# Altium schematic sheet 06-Si5341_PLL.SchDoc(06-Si5341_PLL)
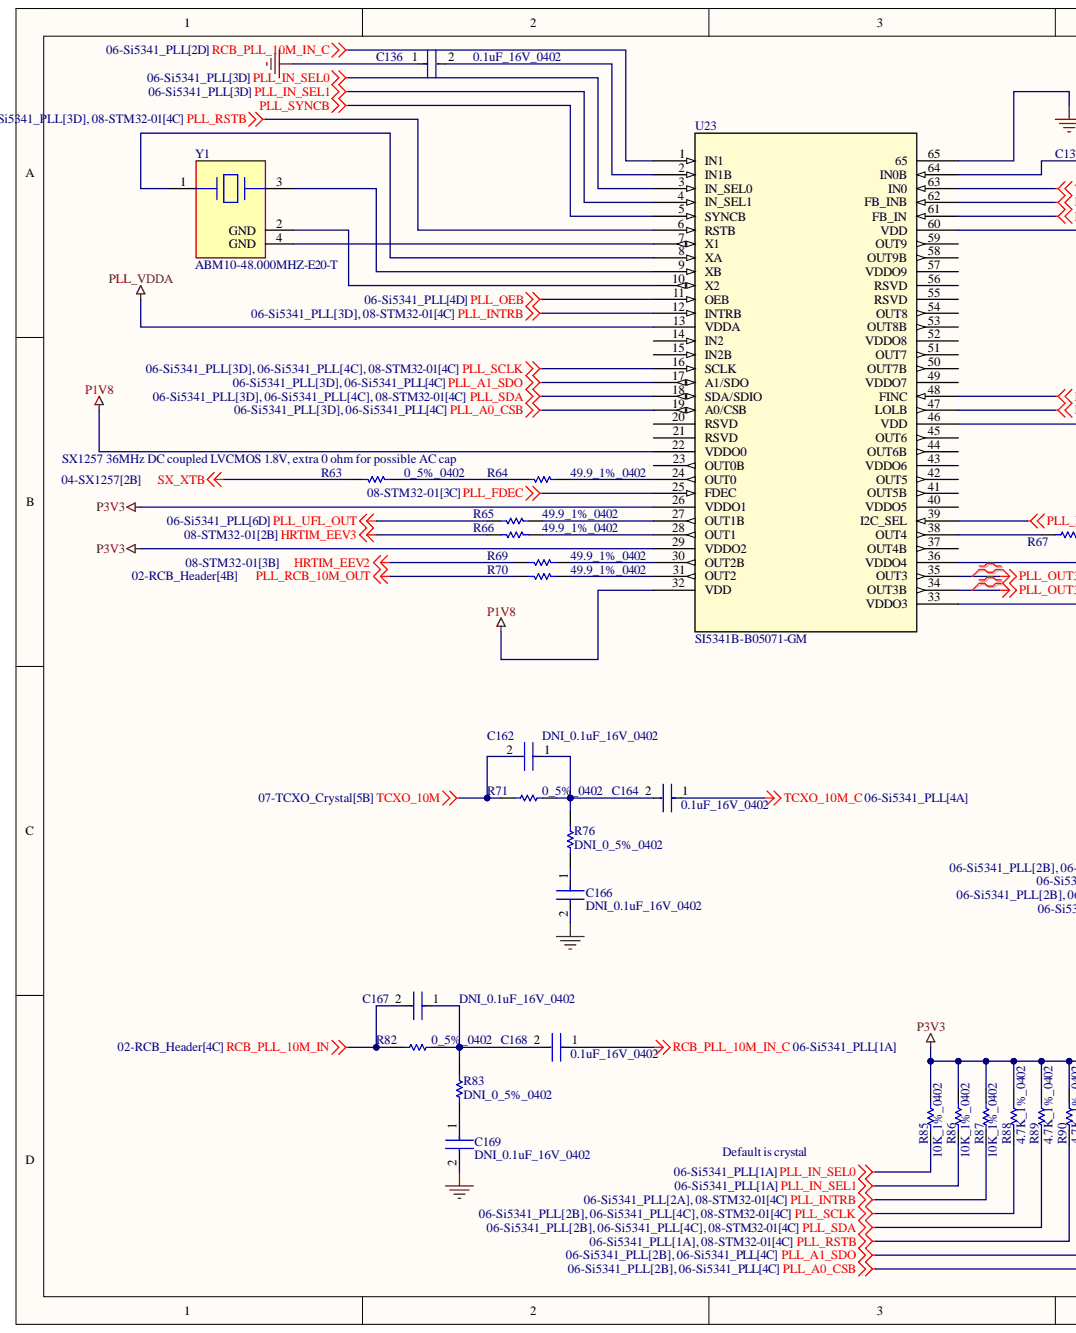
[diagram p0: 06-Si5341_PLL.SchDoc, left]
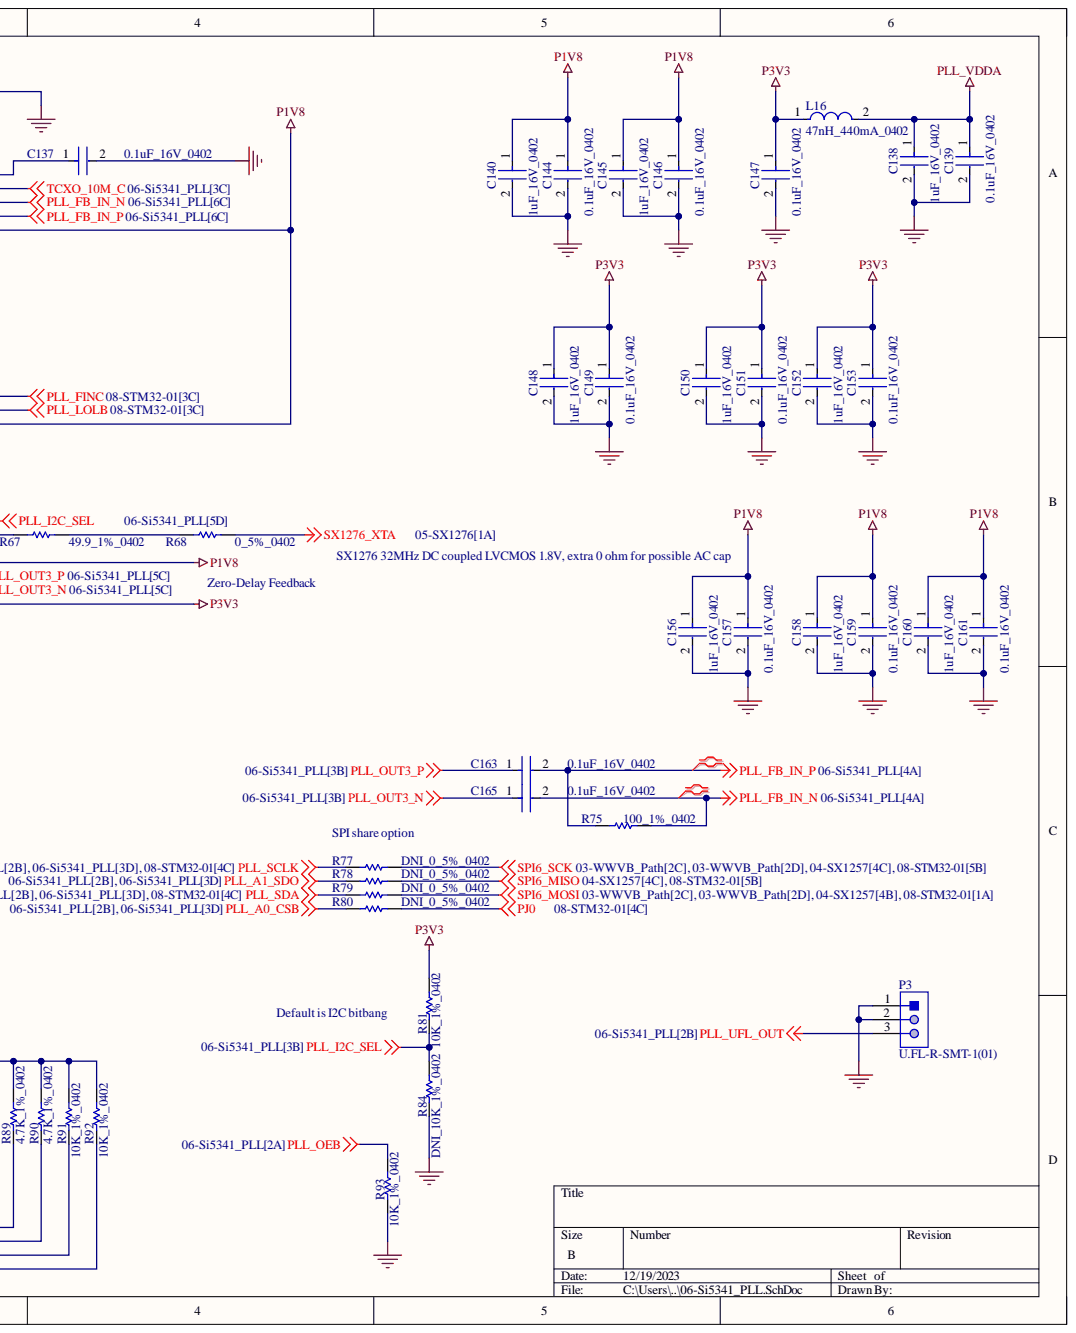
[diagram p1: 06-Si5341_PLL.SchDoc, right]

## Parts
C136  0.1uF_16V_0402  [FP-C0402-BB-MFG]
C137  0.1uF_16V_0402  [FP-C0402-BB-MFG]
C138  1uF_16V_0402  [FP-0402-L_1_0_0_05-W_0_5-IPC_A]
C139  0.1uF_16V_0402  [FP-C0402-BB-MFG]
C140  1uF_16V_0402  [FP-0402-L_1_0_0_05-W_0_5-IPC_A]
C144  0.1uF_16V_0402  [FP-C0402-BB-MFG]
C145  1uF_16V_0402  [FP-0402-L_1_0_0_05-W_0_5-IPC_A]
C146  0.1uF_16V_0402  [FP-C0402-BB-MFG]
C147  0.1uF_16V_0402  [FP-C0402-BB-MFG]
C148  1uF_16V_0402  [FP-0402-L_1_0_0_05-W_0_5-IPC_A]
C149  0.1uF_16V_0402  [FP-C0402-BB-MFG]
C150  1uF_16V_0402  [FP-0402-L_1_0_0_05-W_0_5-IPC_A]
C151  0.1uF_16V_0402  [FP-C0402-BB-MFG]
C152  1uF_16V_0402  [FP-0402-L_1_0_0_05-W_0_5-IPC_A]
C153  0.1uF_16V_0402  [FP-C0402-BB-MFG]
C156  1uF_16V_0402  [FP-0402-L_1_0_0_05-W_0_5-IPC_A]
C157  0.1uF_16V_0402  [FP-C0402-BB-MFG]
C158  1uF_16V_0402  [FP-0402-L_1_0_0_05-W_0_5-IPC_A]
C159  0.1uF_16V_0402  [FP-C0402-BB-MFG]
C160  1uF_16V_0402  [FP-0402-L_1_0_0_05-W_0_5-IPC_A]
C161  0.1uF_16V_0402  [FP-C0402-BB-MFG]
C162  DNI_0.1uF_16V_0402  [FP-C0402-BB-MFG]  (no BOM)
C163  0.1uF_16V_0402  [FP-C0402-BB-MFG]
C164  0.1uF_16V_0402  [FP-C0402-BB-MFG]
C165  0.1uF_16V_0402  [FP-C0402-BB-MFG]
C166  DNI_0.1uF_16V_0402  [FP-C0402-BB-MFG]  (no BOM)
C167  DNI_0.1uF_16V_0402  [FP-C0402-BB-MFG]  (no BOM)
C168  0.1uF_16V_0402  [FP-C0402-BB-MFG]
C169  DNI_0.1uF_16V_0402  [FP-C0402-BB-MFG]  (no BOM)
L16  47nH_440mA_0402  [FP-LQW15AN_80-MFG]
P3  U.FL-R-SMT-1(01)  [HRS-U.FL-R-SMT-3_V]
R63  0_5%_0402  [RESC1005X40X25NL05T10]
R64  49.9_1%_0402  [RESC1005X40X25NL10T10]
R65  49.9_1%_0402  [RESC1005X40X25NL10T10]
R66  49.9_1%_0402  [RESC1005X40X25NL10T10]
R67  49.9_1%_0402  [RESC1005X40X25NL10T10]
R68  0_5%_0402  [RESC1005X40X25NL05T10]
R69  49.9_1%_0402  [RESC1005X40X25NL10T10]
R70  49.9_1%_0402  [RESC1005X40X25NL10T10]
R71  0_5%_0402  [RESC1005X40X25NL05T10]
R75  100_1%_0402  [RESC1005X40X25NL05T10]
R76  DNI_0_5%_0402  [RESC1005X40X25NL05T10]  (no BOM)
R77  DNI_0_5%_0402  [RESC1005X40X25NL05T10]  (no BOM)
R78  DNI_0_5%_0402  [RESC1005X40X25NL05T10]  (no BOM)
R79  DNI_0_5%_0402  [RESC1005X40X25NL05T10]  (no BOM)
R80  DNI_0_5%_0402  [RESC1005X40X25NL05T10]  (no BOM)
R81  10K_1%_0402  [RESC1005X40X25NL10T10]
R82  0_5%_0402  [RESC1005X40X25NL05T10]
R83  DNI_0_5%_0402  [RESC1005X40X25NL05T10]  (no BOM)
R84  DNI_10K_1%_0402  [RESC1005X40X25NL10T10]  (no BOM)
R85  10K_1%_0402  [RESC1005X40X25NL10T10]
R86  10K_1%_0402  [RESC1005X40X25NL10T10]
R87  10K_1%_0402  [RESC1005X40X25NL10T10]
R88  4.7K_1%_0402  [RESC1005X40X25NL10T10]
R89  4.7K_1%_0402  [RESC1005X40X25NL10T10]
R90  4.7K_1%_0402  [RESC1005X40X25NL10T10]
R91  10K_1%_0402  [RESC1005X40X25NL10T10]
R92  10K_1%_0402  [RESC1005X40X25NL10T10]
R93  10K_1%_0402  [RESC1005X40X25NL10T10]
U23  SI5341B-B05071-GM  [QFN64_SI5341_SIL_SKY]
Y1  ABM10-48.000MHZ-E20-T  [FP-ABM10-MFG]

## Nets
GND: C136.1 C137.2 C138.2 C139.2 C140.2 C144.2 C145.2 C146.2 C147.2 C148.2 C149.2 C150.2 C151.2 C152.2 C153.2 C156.2 C157.2 C158.2 C159.2 C160.2 C161.2 C166.2 C169.2 P3.1 P3.2 R84.1 R93.1 U23.65
HRTIM_EEV2: R69.1
HRTIM_EEV3: R66.1
P1V8: C140.1 C144.1 C145.1 C146.1 C156.1 C157.1 C158.1 C159.1 C160.1 C161.1 U23.22(VDDO0) U23.32(VDD) U23.36(VDDO4) U23.46(VDD) U23.60(VDD)
P3V3: C147.1 C148.1 C149.1 C150.1 C151.1 C152.1 C153.1 L16.1 R81.2 R85.2 R86.2 R87.2 R88.2 R89.2 R90.2 R91.2 R92.2 U23.26(VDDO1) U23.29(VDDO2) U23.33(VDDO3)
PJ0: R80.2
PLL_A0_CSB: R80.1 R92.1 U23.19(A0/CSB)
PLL_A1_SDO: R78.1 R91.1 U23.17(A1/SDO)
PLL_FB_IN_N: C165.2 R75.2 U23.62(FB_INB)
PLL_FB_IN_P: C163.2 R75.1 U23.61(FB_IN)
PLL_FDEC: U23.25(FDEC)
PLL_FINC: U23.48(FINC)
PLL_I2C_SEL: R81.1 R84.2 U23.39(I2C_SEL)
PLL_IN_SEL0: R85.1 U23.3(IN_SEL0)
PLL_IN_SEL1: R86.1 U23.4(IN_SEL1)
PLL_INTRB: R87.1 U23.12(INTRB)
PLL_LOLB: U23.47(LOLB)
PLL_OEB: R93.2 U23.11(OEB)
PLL_OUT3_N: C165.1 U23.34(OUT3B)
PLL_OUT3_P: C163.1 U23.35(OUT3)
PLL_RCB_10M_OUT: R70.1
PLL_RSTB: R90.1 U23.6(RSTB)
PLL_SCLK: R77.1 R88.1 U23.16(SCLK)
PLL_SDA: R79.1 R89.1 U23.18(SDA/SDIO)
PLL_SYNCB: U23.5(SYNCB)
PLL_UFL_OUT: P3.3 R65.1
PLL_VDDA: C138.1 C139.1 L16.2 U23.13(VDDA)
RCB_PLL_10M_IN: C167.2 R82.1
RCB_PLL_10M_IN_C: C168.1 U23.1(IN1)
SPI6_MISO: R78.2
SPI6_MOSI: R79.2
SPI6_SCK: R77.2
SX1276_XTA: R68.2
SX_XTB: R63.2
TCXO_10M: C162.2 R71.1
TCXO_10M_C: C164.1 U23.63(IN0)
NetC136_2: C136.2 U23.2(IN1B)
NetC137_1: C137.1 U23.64(IN0B)
NetC162_1: C162.1 C164.2 R71.2 R76.2
NetC166_1: C166.1 R76.1
NetC167_1: C167.1 C168.2 R82.2 R83.2
NetC169_1: C169.1 R83.1
NetR63_1: R63.1 R64.1
NetR64_2: R64.2 U23.24(OUT0)
NetR65_2: R65.2 U23.27(OUT1B)
NetR66_2: R66.2 U23.28(OUT1)
NetR67_1: R67.1 R68.1
NetR67_2: R67.2 U23.38(OUT4)
NetR69_2: R69.2 U23.30(OUT2B)
NetR70_2: R70.2 U23.31(OUT2)
NetU23_7: U23.7(X1) Y1.4(GND)
NetU23_8: U23.8(XA) Y1.1
NetU23_9: U23.9(XB) Y1.3
NetU23_10: U23.10(X2) Y1.2(GND)

Not connected: U23 14(IN2) 15(IN2B) 20(RSVD) 21(RSVD) 23(OUT0B) 37(OUT4B) 40(VDDO5) 41(OUT5B) 42(OUT5) 43(VDDO6) 44(OUT6B) 45(OUT6) 49(VDDO7) 50(OUT7B) 51(OUT7) 52(VDDO8) 53(OUT8B) 54(OUT8) 55(RSVD) 56(RSVD) 57(VDDO9) 58(OUT9B) 59(OUT9)

## Library data of the parts
C136 C137 C139 C144 C146 C147 C149 C151 C153 C157 C159 C161-C169 = CMP-1034-02068-3: CAP CER 0.1UF 16V 5% X7R 0402; Manufacturer: Kemet; Manufacturer Part Number: C0402C104J4RACTU; Capacitance: 0.10 μF; Material: Ceramic; Temperature Coefficient: 15%; Tolerance: 0.05; Value: 0.10 μF; Automotive: No; Category: Cap; ComponentLink2Description: Datasheet; ComponentLink2URL: https://content.kemet.com/datasheets/KEM_C1002_X7R_SMD.pdf; Device Class L1: Passive Components; Device Class L2: Capacitors; Device Class L3: Ceramic Capacitors; Digikey Description: CAP CER 0.1UF 16V 5% X7R 0402; Height: 0.55mm; Ipc Land Pattern Name: CAPC10050X50; Lead Free: Yes; Library Name: Altium Content Vault; Mouser Description: CAP CER 0.1UF 16V X7R 0402; Octopart: https://octopart.com/c0402c104j4ractu-kemet-12573893; Package: C0402-BB; RoHS: TRUE; Temperature: -55°C to +125°C; Temperature Characteristic: X7R; Temperature Range High: +125°C; Temperature Range Low: -55°C; Voltage Rating: 16 V
  C136 C137 C139 C144 C146 C147 C149 C151 C153 C157 C159 C161 C163-C165 C168: Component Kind: Standard
C138 C140 C145 C148 C150 C152 C156 C158 C160 = CMP-14477-000104-2: None; Capacitance: 1uF; Dielectric: X5R; Tolerance: 10%; Voltage Rating: 16V; Case Code (Imperial): 0402; Case Code (Metric): 1005; Case/Package: 0402; Component Kind: Standard; Construction: Flat; Depth: 0.5mm; Halogen Free: Halogen Free; Height: 0.5mm; Length: 1mm; Library Name: Altium Content Vault; Max Operating Temperature: 85°C; Min Operating Temperature: -55°C; Mount: Surface Mount; Number of Pins: 2; Package Quantity: 1; Packaging: Tape and Reel; Radiation Hardening: No; RoHS Compliant: Yes; Series: M; Termination: SMD/SMT; Thickness: 0.022inch; Voltage Rating (DC): 16V; Width: 0.02inch
L16 = CMP-06042-005115-1: Wire Wound RF Inductor 47nH ±5% 440mA 0.648Ω 0402 (1005); Core Material: Non-Magnetic; DC Resistance (DCR): 648mR; Q Factor: 25; Self Resonant Frequency: 3.2GHz; Shielding: Unshielded; Test Frequency: 100MHz; Case Code (Imperial): 0402; Case Code (Metric): 1005; Case/Package: 0402; Component Kind: Standard; Current Rating: 440mA; Height: 0.5mm; Height - Seated (Max): 0.024inch; Inductance: 47nH; Length: 0.039inch; Library Name: Altium Content Vault; Max DC Current: 440mA; Max Operating Temperature: 125°C; Min Operating Temperature: -55°C; Mount: Surface Mount; Package Quantity: 1; Packaging: Tape and Reel; Resistor Type: Wirewound; Termination: SMD/SMT; Tolerance: 5%; Width: 0.024inch
P3 = CMP-2000-05153-1: Ultra Small Coaxial Connector, 6 GHz, -40 to 90 degC, 3-Pin SMD, RoHS, Bulk; Manufacturer: Hirose Electric; Body Finish: Silver; Body Material: PhosphorBronze; Center Contact Plating: Gold; Connector Style: U.FL; Connector Type: Jack,MalePin; Contact Termination: Solder; Dielectric Material: LiquidCrystalPolymer(LCP); Dynamic Catalog: U.FLSeries; Featured Product: HiroseU.FLSeries; Frequency - Max: 6GHz; Impedance: 50Ohm; Minimum Quantity: UltraMiniatureCoaxial; Other Names: H122041  U.FL-R-SMT-1(01)-ND; Category: Connectors,Interconnects; Center Contact Material: PhosphorBronze; Component Kind: Standard; Datasheet URL: https://datasheet.ciiva.com/26945/getdatasheetpartid-475239-26945414.pdf; Datasheet Version: Feb-09; Family: CoaxialConnectors(RF); Fastening Type: Snap-On; Housing Color: Silver; Library Name: Altium Content Vault; Manufacturer URL: https://www.hirose.com; Mounting Type: SurfaceMount; Online Catalog: U.FLSeries; Operating Temperature: -40degC~90degC; Package Description: 3-Pin Surface Mount Device, Body 2.6 x 2.6 mm; Package Reference: U.FL-R-SMT; Packaging: Bulk; Quantity Available: Bulk; Series: U.FL; Shield Termination: Solder; Standard Package: 100; Unit PriceUSD: U.FL
R63 R68 R71 R76-R80 R82 R83 = CMP-2002-06457-1: Manufacturer: Panasonic; Part Number: ERJ-U020R00X; Library Name: Altium Content Vault
  R63 R68 R71 R82: Component Kind: Standard
R64-R67 R69 R70 = CMP-2002-04448-1: Manufacturer: KOA Speer; Part Number: RK73H1ETTP49R9F; Component Kind: Standard; Library Name: Altium Content Vault
R75 = CMP-2002-07853-1: Manufacturer: Yageo; Part Number: RC0402FR-13100RL; Component Kind: Standard; Library Name: Altium Content Vault
R81 R84-R87 R91-R93 = CMP-2002-04694-1: Manufacturer: Bourns; Part Number: CR0402-FX-1002GLF; Library Name: Altium Content Vault
  R81 R85-R87 R91-R93: Component Kind: Standard
R88-R90 = CMP-2002-05217-1: Manufacturer: Bourns; Part Number: CR0402-FX-4701GLF; Component Kind: Standard; Library Name: Altium Content Vault
U23 = SI5341B-B05071-GM: No Description Available; Mfr_Name: Skyworks; Component Kind: Standard; Library Name: SI5341B-B05071-GM.SchLib; Manufacturer_Part_Number: SI5341B-B05071-GM; RefDes: RefDes; Type: DEV; Copyright: Copyright (C) 2023 Ultra Librarian. All rights reserved.
Y1 = CMP-27762-011866-1: Crystal 48MHz ±20ppm 10pF SMD-4 2.5mm x 2mm; ESR (Equivalent Series Resistance): 70R; Case/Package: CSMD; Component Kind: Standard; Depth: 2mm; Frequency: 48MHz; Frequency Stability: 20ppm; Frequency Tolerance: 20ppm; Height: 0.02inch; Lead Free: Lead Free; Length: 2.5mm; Library Name: Altium Content Vault; Load Capacitance: 10pF; Max Operating Temperature: 70°C; Min Operating Temperature: -20°C; Mount: Surface Mount; Number of Pins: 4; Operating Frequency: 48 MHz; Package Quantity: 1000; Packaging: Tape and Reel; RoHS Compliant: Yes; Series: ABM10; Series Resistance: 70R; Termination: SMD/SMT; Tolerance: 20ppm; Width: 0.079inch
